# Altium schematic sheet Power Schematic.SchDoc("Power Schematic")
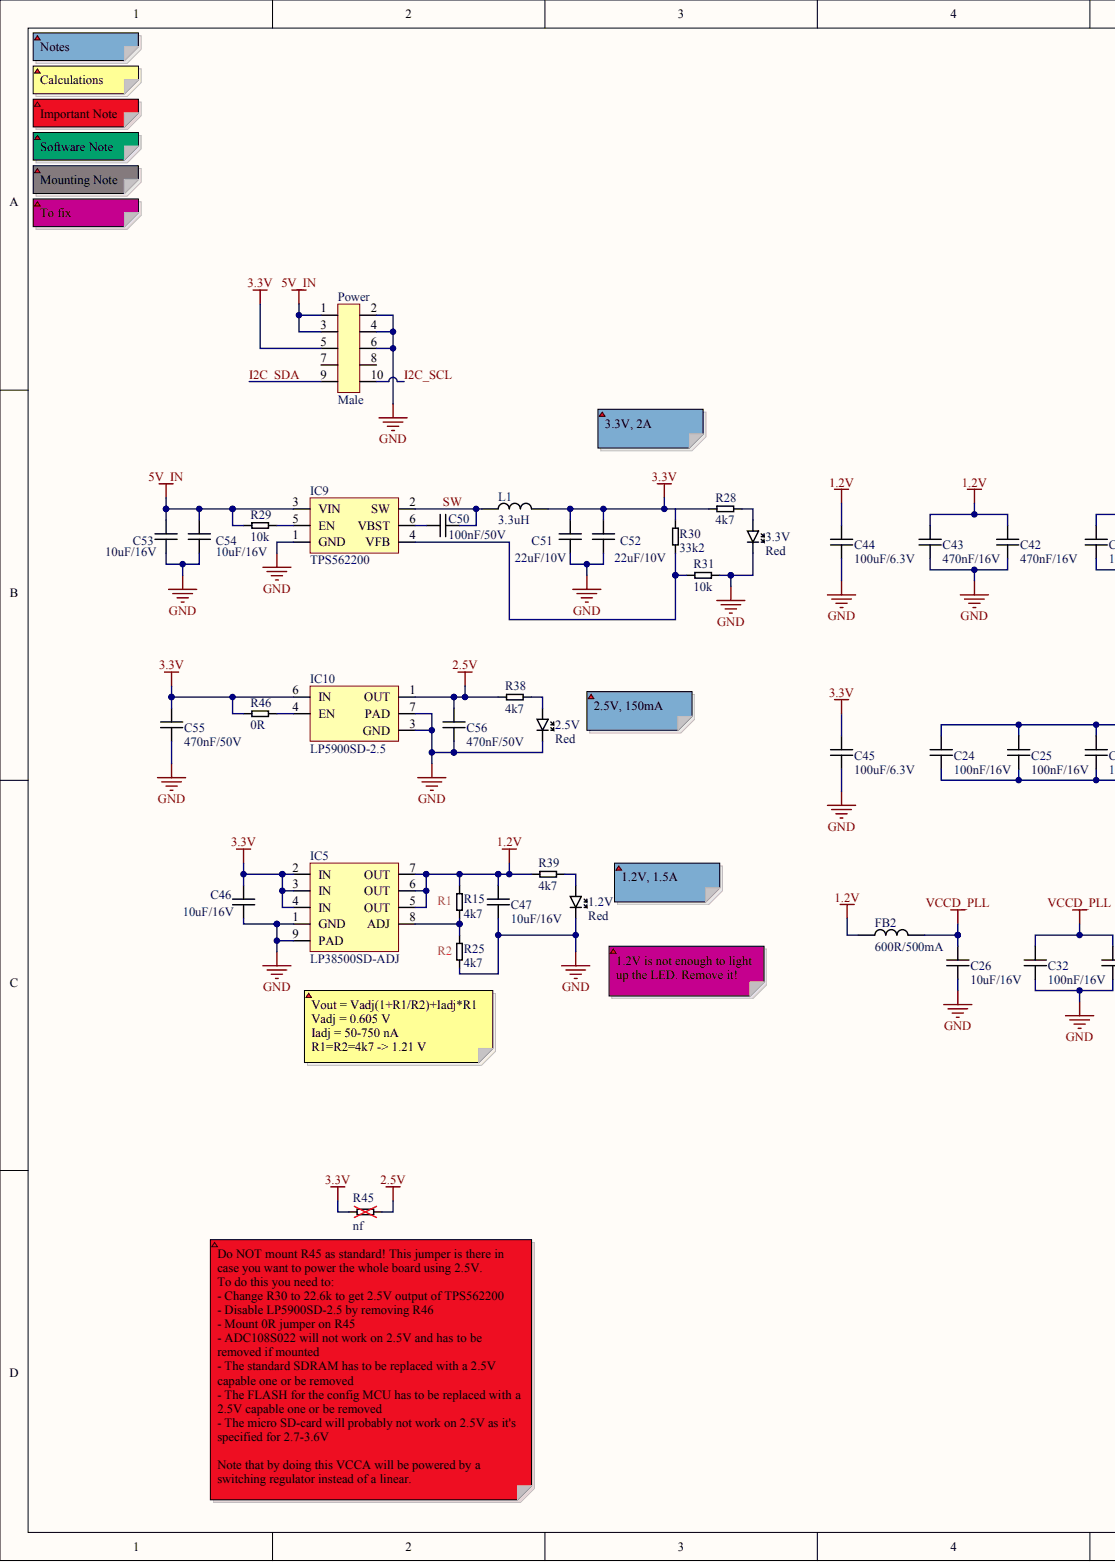
[diagram p0: Power Schematic.SchDoc, left]
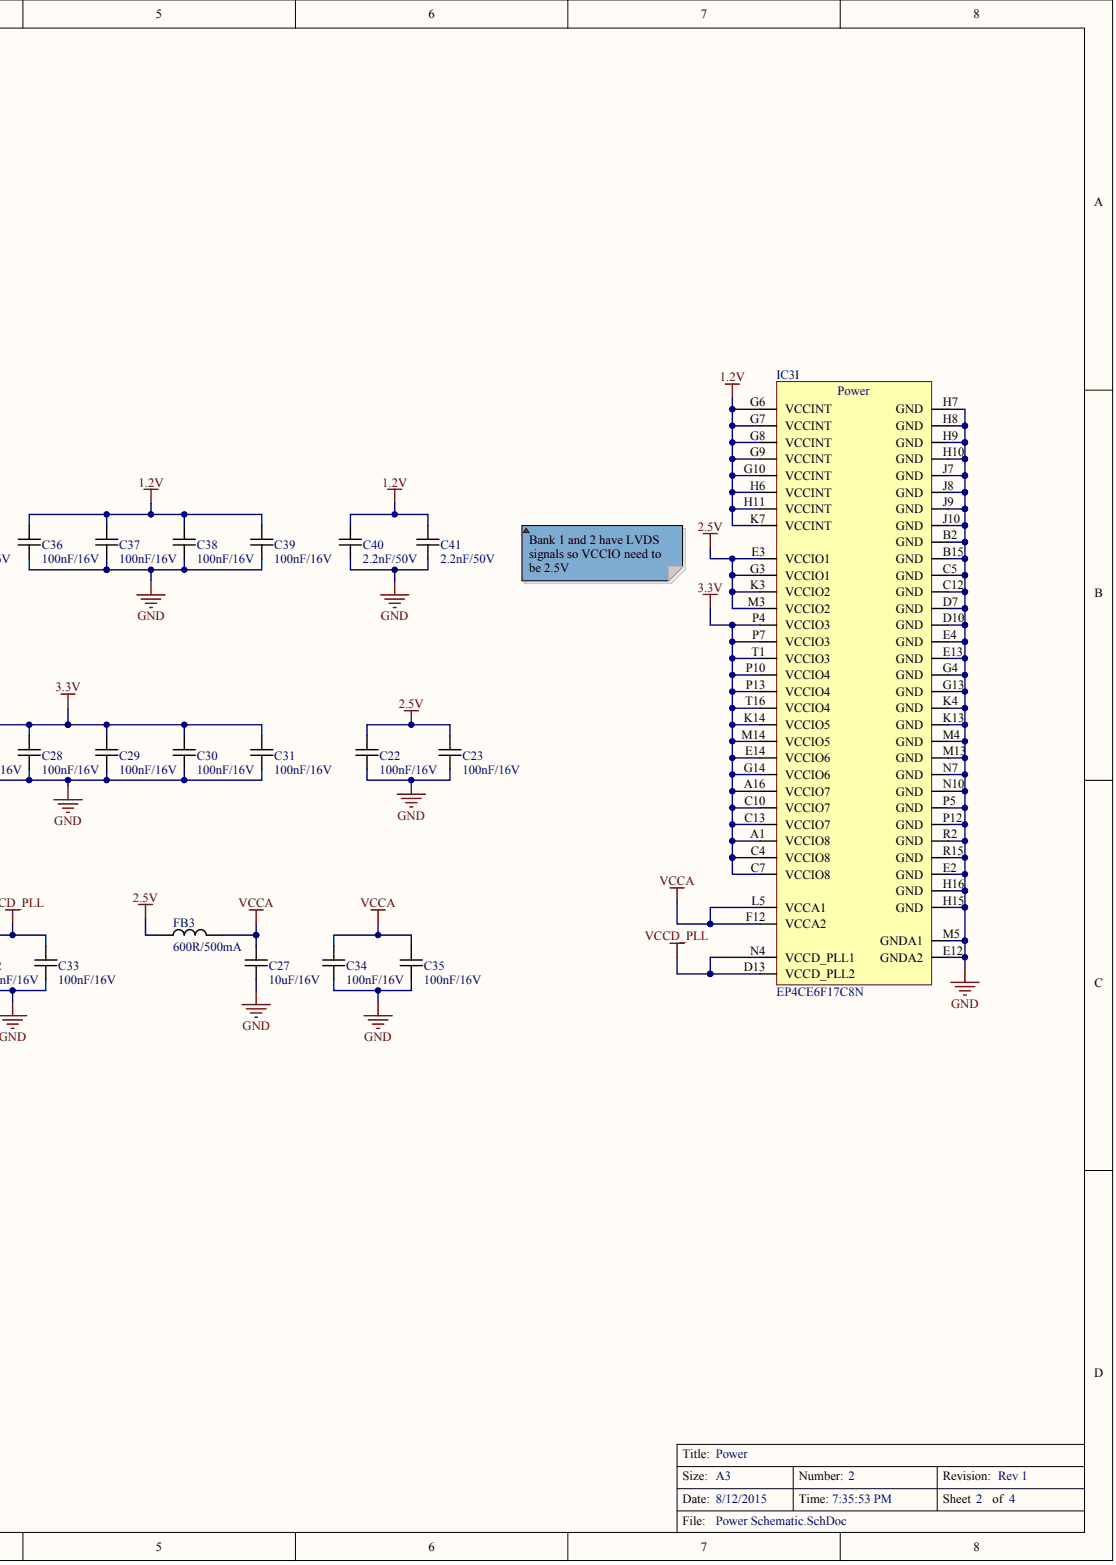
[diagram p1: Power Schematic.SchDoc, right]

## Parts
1.2V  value Red  (hidden comment: LED_0603_Red)
2.5V  value Red  (hidden comment: LED_0603_Red)
3.3V  value Red  (hidden comment: LED_0603_Red)
C22  value 100nF/16V  [0402]  (hidden comment: Cap_0402_100nF/16V)
C23  value 100nF/16V  [0402]  (hidden comment: Cap_0402_100nF/16V)
C24  value 100nF/16V  [0402]  (hidden comment: Cap_0402_100nF/16V)
C25  value 100nF/16V  [0402]  (hidden comment: Cap_0402_100nF/16V)
C26  value 10uF/16V  [0805]  (hidden comment: Cap_0805_10uF/16V)
C27  value 10uF/16V  [0805]  (hidden comment: Cap_0805_10uF/16V)
C28  value 100nF/16V  [0402]  (hidden comment: Cap_0402_100nF/16V)
C29  value 100nF/16V  [0402]  (hidden comment: Cap_0402_100nF/16V)
C30  value 100nF/16V  [0402]  (hidden comment: Cap_0402_100nF/16V)
C31  value 100nF/16V  [0402]  (hidden comment: Cap_0402_100nF/16V)
C32  value 100nF/16V  [0402]  (hidden comment: Cap_0402_100nF/16V)
C33  value 100nF/16V  [0402]  (hidden comment: Cap_0402_100nF/16V)
C34  value 100nF/16V  [0402]  (hidden comment: Cap_0402_100nF/16V)
C35  value 100nF/16V  [0402]  (hidden comment: Cap_0402_100nF/16V)
C36  value 100nF/16V  [0402]  (hidden comment: Cap_0402_100nF/16V)
C37  value 100nF/16V  [0402]  (hidden comment: Cap_0402_100nF/16V)
C38  value 100nF/16V  [0402]  (hidden comment: Cap_0402_100nF/16V)
C39  value 100nF/16V  [0402]  (hidden comment: Cap_0402_100nF/16V)
C40  value 2.2nF/50V  [0402]  (hidden comment: Cap_0402_2.2nF/50V)
C41  value 2.2nF/50V  [0402]  (hidden comment: Cap_0402_2.2nF/50V)
C42  value 470nF/16V  [0402]  (hidden comment: Cap_0402_470nF/16V)
C43  value 470nF/16V  [0402]  (hidden comment: Cap_0402_470nF/16V)
C44  value 100uF/6.3V  [1210]  (hidden comment: Cap_1210_100uF/6.3V)
C45  value 100uF/6.3V  [1210]  (hidden comment: Cap_1210_100uF/6.3V)
C46  value 10uF/16V  [0805]  (hidden comment: Cap_0805_10uF/16V)
C47  value 10uF/16V  [0805]  (hidden comment: Cap_0805_10uF/16V)
C50  value 100nF/50V  [0603]  (hidden comment: Cap_0603_100nF/50V)
C51  value 22uF/10V  [0805]  (hidden comment: Cap_0805_22uF/10V)
C52  value 22uF/10V  [0805]  (hidden comment: Cap_0805_22uF/10V)
C53  value 10uF/16V  [0805]  (hidden comment: Cap_0805_10uF/16V)
C54  value 10uF/16V  [0805]  (hidden comment: Cap_0805_10uF/16V)
C55  value 470nF/50V  [0603]  (hidden comment: Cap_0603_470nF/50V)
C56  value 470nF/50V  [0603]  (hidden comment: Cap_0603_470nF/50V)
FB2  value 600R/500mA  [0603]  (hidden comment: Ferrite_Bead_0603_600R_500mA)
FB3  value 600R/500mA  [0603]  (hidden comment: Ferrite_Bead_0603_600R_500mA)
IC3  EP4CE6F17C8N  [FPGA-256]  (part I)
IC5  LP38500SD-ADJ  [WSON-8]
IC9  TPS562200  [SOT-23-6]
IC10  LP5900SD-2.5  [WSON-6]
L1  value 3.3uH  [SMD SRN4028 4.8x4.8mm]  (hidden comment: Inductor_SRR4028_3.3uH)
Power  value Male  (hidden comment: Connector 2x5)
R15  value 4k7  [0603]  (hidden comment: Res_0603_4k7)
R25  value 4k7  [0603]  (hidden comment: Res_0603_4k7)
R28  value 4k7  [0603]  (hidden comment: Res_0603_4k7)
R29  value 10k  [0603]  (hidden comment: Res_0603_10k)
R30  value 33k2  [0603]  (hidden comment: Res_0603_33k2)
R31  value 10k  [0603]  (hidden comment: Res_0603_10k)
R38  value 4k7  [0603]  (hidden comment: Res_0603_4k7)
R39  value 4k7  [0603]  (hidden comment: Res_0603_4k7)
R45  value nf  [0603]  (hidden comment: Res_0603_nf)
R46  value 0R  [0603]  (hidden comment: Res_0603_0R)

## Nets
1.2V: C36.2 C37.2 C38.2 C39.2 C40.2 C41.2 C42.2 C43.2 C44.2 C47.2 FB2.1 IC3.G6(VCCINT) IC3.G7(VCCINT) IC3.G8(VCCINT) IC3.G9(VCCINT) IC3.G10(VCCINT) IC3.H6(VCCINT) IC3.H11(VCCINT) IC3.K7(VCCINT) IC5.5(OUT) IC5.6(OUT) IC5.7(OUT) R15.2 R39.2
2.5V: C22.2 C23.2 C56.2 FB3.1 IC3.E3(VCCIO1) IC3.G3(VCCIO1) IC3.K3(VCCIO2) IC3.M3(VCCIO2) IC10.1(OUT) R38.2 R45.2
3.3V: C24.2 C25.2 C28.2 C29.2 C30.2 C31.2 C45.2 C46.2 C51.2 C52.2 C55.2 IC3.A1(VCCIO8) IC3.A16(VCCIO7) IC3.C4(VCCIO8) IC3.C7(VCCIO8) IC3.C10(VCCIO7) IC3.C13(VCCIO7) IC3.E14(VCCIO6) IC3.G14(VCCIO6) IC3.K14(VCCIO5) IC3.M14(VCCIO5) IC3.P4(VCCIO3) IC3.P7(VCCIO3) IC3.P10(VCCIO4) IC3.P13(VCCIO4) IC3.T1(VCCIO3) IC3.T16(VCCIO4) IC5.2(IN) IC5.3(IN) IC5.4(IN) IC10.6(IN) L1.2 Power.5 R28.2 R30.2 R45.1 R46.1
5V_IN: C53.2 C54.2 IC9.3(VIN) Power.1 Power.3 R29.2
GND: 1.2V.CATHODE 2.5V.CATHODE 3.3V.CATHODE C22.1 C23.1 C24.1 C25.1 C26.1 C27.1 C28.1 C29.1 C30.1 C31.1 C32.1 C33.1 C34.1 C35.1 C36.1 C37.1 C38.1 C39.1 C40.1 C41.1 C42.1 C43.1 C44.1 C45.1 C46.1 C47.1 C51.1 C52.1 C53.1 C54.1 C55.1 C56.1 IC3.B2(GND) IC3.B15(GND) IC3.C5(GND) IC3.C12(GND) IC3.D7(GND) IC3.D10(GND) IC3.E2(GND) IC3.E4(GND) IC3.E12(GNDA2) IC3.E13(GND) IC3.G4(GND) IC3.G13(GND) IC3.H7(GND) IC3.H8(GND) IC3.H9(GND) IC3.H10(GND) IC3.H15(GND) IC3.H16(GND) IC3.J7(GND) IC3.J8(GND) IC3.J9(GND) IC3.J10(GND) IC3.K4(GND) IC3.K13(GND) IC3.M4(GND) IC3.M5(GNDA1) IC3.M13(GND) IC3.N7(GND) IC3.N10(GND) IC3.P5(GND) IC3.P12(GND) IC3.R2(GND) IC3.R15(GND) IC5.1(GND) IC5.9(PAD) IC9.1(GND) IC10.3(GND) IC10.7(PAD) Power.2 Power.4 Power.6 R25.1 R31.2
VCCA: C27.2 C34.2 C35.2 FB3.2 IC3.F12(VCCA2) IC3.L5(VCCA1)
VCCD_PLL: C26.2 C32.2 C33.2 FB2.2 IC3.D13(VCCD_PLL2) IC3.N4(VCCD_PLL1)
I2C_SCL: Power.10
I2C_SDA: Power.9
SW: C50.2 IC9.2(SW) L1.1
Net1.2V_ANODE: 1.2V.ANODE R39.1
Net2.5V_ANODE: 2.5V.ANODE R38.1
Net3.3V_ANODE: 3.3V.ANODE R28.1
NetC50_1: C50.1 IC9.6(VBST)
NetIC5_8: IC5.8(ADJ) R15.1 R25.2
NetIC9_4: IC9.4(VFB) R30.1 R31.1
NetIC9_5: IC9.5(EN) R29.1
NetIC10_4: IC10.4(EN) R46.2

Not connected: Power 7 8

## Library data of the parts
1.2V 2.5V 3.3V = (no symbol name): Part No.: APT1608SRCPRV; Manufacturer: Kingbright; RS: 654-5420; DigiKey: 754-1122-1-ND; Price SEK (No VAT): 1; Mouser: 604-APT1608SRCPRV
C22-C25 C28-C39 = (no symbol name): Part No.: C1005X7R1C104K050BC; Manufacturer: TDK; RS: 741-4415 (500-pack); DigiKey: 445-4952-1-ND; Mouser: 810-C1005X7R1C104K; Price SEK (No VAT): 0,2
C26 C27 C46 C47 C53 C54 = (no symbol name): Part No.: GRM21BR61C106KE15L; Manufacturer: Murata; DigiKey: 490-3886-1-ND; Price SEK (No VAT): 1,5; Mouser: 81-GRM21BR61C106KE15
C40 C41 = (no symbol name): Part No.: C1005X7R1H222K050BA; Manufacturer: TDK; DigiKey: 445-1257-1-ND; Mouser: 810-C1005X7R1H222K; Price SEK (No VAT): 0,2; RS: *
C42 C43 = (no symbol name): Part No.: C1005X5R1C474K050BC; Manufacturer: TDK; RS: 788-2837 (50-pack); DigiKey: 445-4976-1-ND; Mouser: 810-C1005X5R1C474K; Price SEK (No VAT): 0,5
C44 C45 = (no symbol name): Part No.: C1210C107M9PACTU; Manufacturer: Kemet; RS: 691-1243 (5-pack); DigiKey: 399-4697-1-ND; Mouser: 80-C1210C107M9P; Price SEK (No VAT): 10
C50 = (no symbol name): Part No.: C1608X7R1H104K080AA; Manufacturer: TDK; RS: 741-4459 (500-pack); DigiKey: 445-1314-1-ND; Mouser: 810-C1608X7R1H104K; Price SEK (No VAT): 0,2
C51 C52 = (no symbol name): Part No.: C2012X5R1A226M125AB; Manufacturer: TDK; DigiKey: 445-7665-1-ND; Mouser: 810-C2012X5R1A226M-3; Price SEK (No VAT): 4
C55 C56 = (no symbol name): Part No.: C1608X7R1H474K080AC; Manufacturer: TDK; RS: 788-3007 (50-pack); DigiKey: 445-5952-1-ND; Mouser: 810-C1608X7R1H474K; Price SEK (No VAT): 0,5
FB2 FB3 = (no symbol name): Part No.: MMZ1608B601C; Manufacturer: TDK; RS: 786-5015; Price SEK (No VAT): 1; Mouser: 810-MMZ1608B601C; DigiKey: 445-2166-1-ND; Farnell: 1669687
IC3 = (no symbol name): Manufacturer: Altera; RS: 830-3530; Part No.: EP4CE6F17C8N; Mouser: 989-EP4CE6F17C8N; DigiKey: 544-2828-ND; Price SEK (No VAT): 145; Farnell: 2100853; Value: EP4CE6F17C8N
IC5 = (no symbol name): Manufacturer: TI; RS: 825-8294 (5-pack); DigiKey: LP38500SD-ADJ/NOPBCT-ND; Part No.: LP38500SD-ADJ; Price SEK (No VAT): 16; Mouser: 926-38500SD-ADJ/NOPB; Farnell: 2382955; Value: LP38500SD-ADJ
IC9 = (no symbol name): Part No.: TPS562200DDCR; Manufacturer: TI; DigiKey: 296-39631-1-ND; Price SEK (No VAT): 12; Mouser: 595-TPS562200DDCR; RS: *; Value: TPS562200
IC10 = (no symbol name): Manufacturer: TI; RS: 651-5132 (5-pack); DigiKey: LP5900SD-2.5/NOPBCT-ND; Part No.: LP5900SD-2.5; Price SEK (No VAT): 7; Mouser: 926-5900SD-2.5/NOPB; Farnell: 2382973; Value: LP5900SD-2.5
L1 = (no symbol name): Part No.: SRR4028-3R3Y; Manufacturer: Bourns; RS: 727-2022 (5-pack); Mouser: 652-SRR4028-3R3Y; Price SEK (No VAT): 6; DigiKey: SRR4028-3R3YCT-ND; Farnell: *
R15 R25 R28 R29 R31 R38 R39 = (no symbol name): Part No.: CRCW060310K0FKEA; Manufacturer: Vishay; Price SEK (No VAT): 0,1; DigiKey: 541-10.0KHCT-ND; Mouser: 71-CRCW0603-10K-E3
R30 = (no symbol name): Part No.: CRCW060333K2FKEA; Manufacturer: Vishay; Price SEK (No VAT): 0,1; Mouser: 71-CRCW0603-33.2K-E3; DigiKey: 541-33.2KHCT-ND
R46 = (no symbol name): Part No.: CRCW06030000Z0EA; Manufacturer: Vishay; Price SEK (No VAT): 0,1; DigiKey: 541-0.0GCT-ND; Mouser: 71-CRCW0603-0-E3
Power R45 = (no symbol name)
  R45: Price SEK (No VAT): 0
